# Altium schematic sheet LEDs.SchDoc
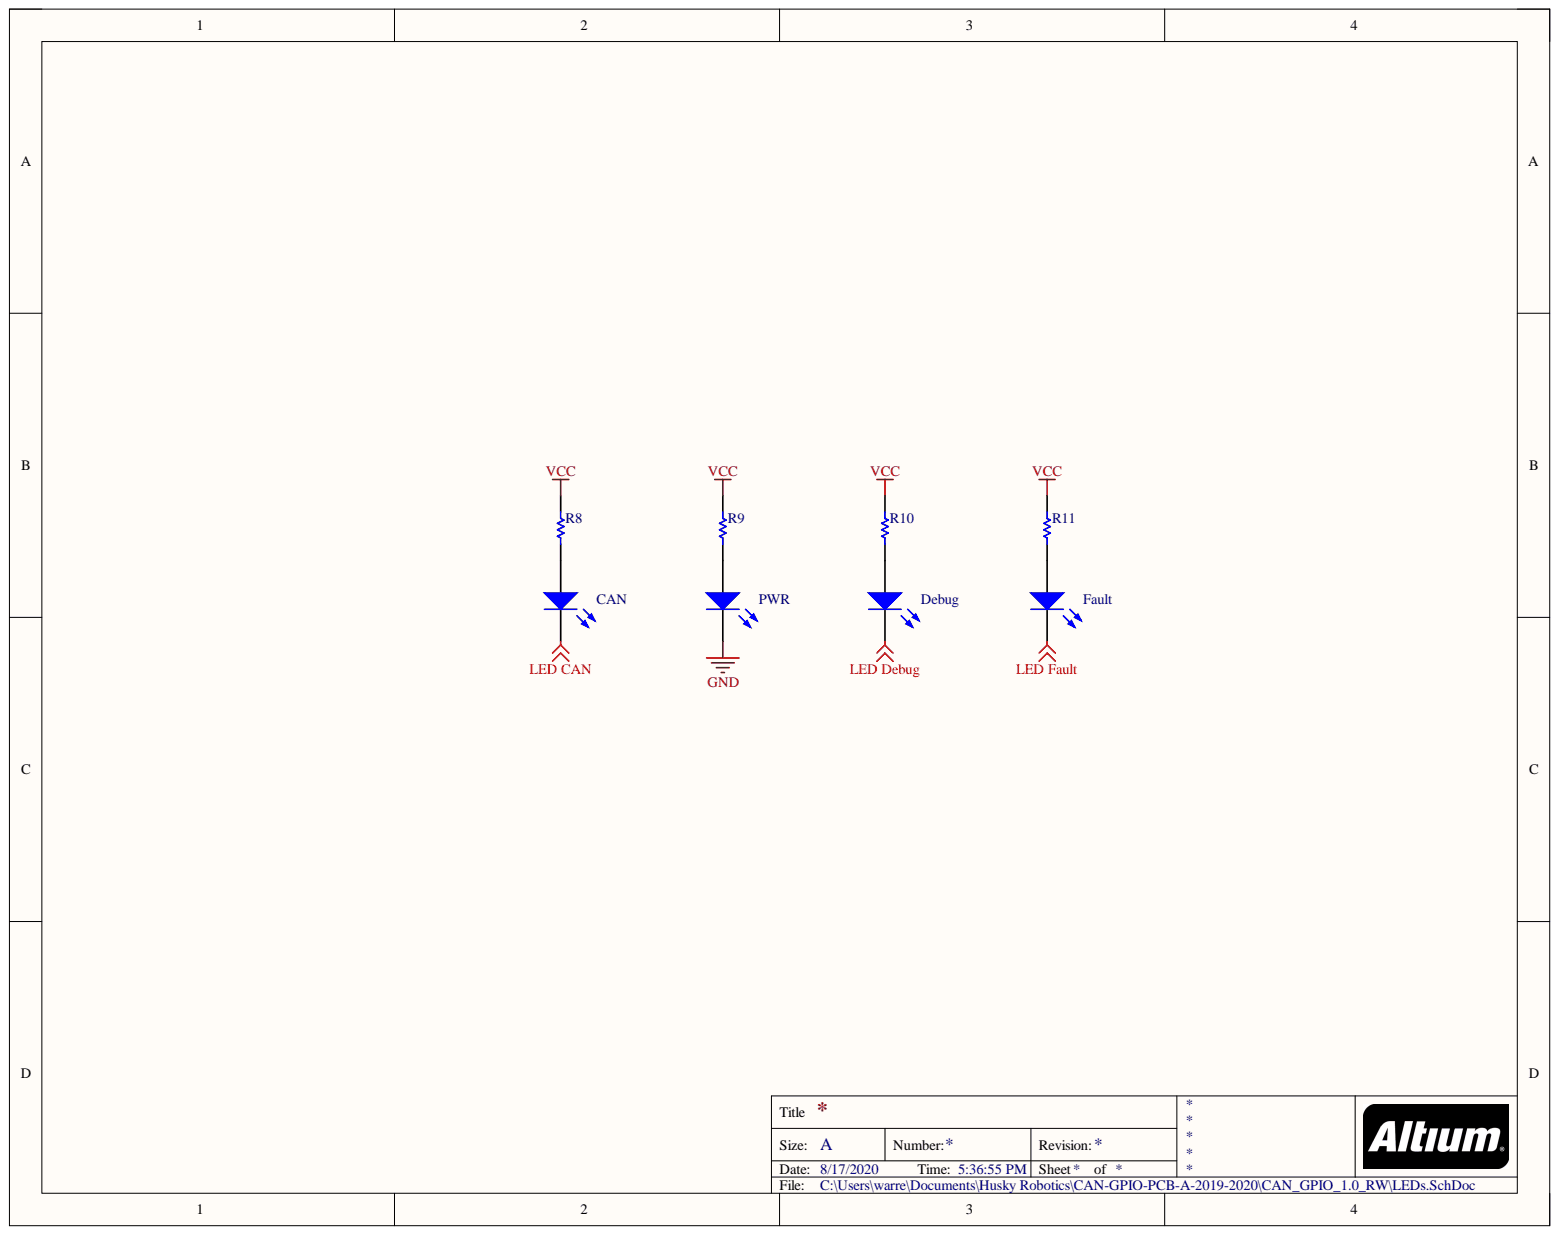
[diagram p0: LEDs.SchDoc]

## Parts
CAN  LED  [0805_A]
Debug  LED  [0805_A]
Fault  LED  [0805_A]
PWR  LED  [0805_A]  (value not drawn)
R8  Resistor 0805  [RESC0805(2012)_N]  (value not drawn)
R9  Resistor 0805  [RESC0805(2012)_N]  (value not drawn)
R10  Resistor 0805  [RESC0805(2012)_N]  (value not drawn)
R11  Resistor 0805  [RESC0805(2012)_N]  (value not drawn)

## Nets
GND: PWR.1
LED CAN: CAN.1
LED Debug: Debug.1
LED Fault: Fault.1
VCC: R8.1 R9.1 R10.1 R11.1
NetCAN_2: CAN.2 R8.2
NetDebug_2: Debug.2 R10.2
NetFault_2: Fault.2 R11.2
NetPWR_2: PWR.2 R9.2

## Library data of the parts
CAN Fault = LED: Standard 0805 LED; Manufacturer: Wuerth Elektronik; PartNumber: 150080SS75000; Component Kind: Standard; PackageReference: 0805_A; Library Name: Husky Robotics Standard Library 2019-2020.IntLib
  CAN: Color: Blue
  Fault: Color: Super Red; ComponentLink1Description: Manufacturer URL; ComponentLink1URL: http://www.we-online.com; ComponentLink2Description: Datasheet; ComponentLink2URL: http://katalog.we-online.com/led/datasheet/150080SS75000.pdf
Debug PWR = LED: Standard 0805 LED; Component Kind: Standard; PackageReference: 0805_A; Library Name: Husky Robotics Standard Library 2019-2020.IntLib
  Debug: Color: Green
  PWR: Color: Yellow
R8-R11 = Resistor 0805: Generic 0805 Resistor; Case-EIA: 0805; Case-Metric: 2012; Power: 0.125 W; Tolerance: 5 %; Value: 120R; Component Kind: Standard; Technology: SMT; Library Name: Husky Robotics Standard Library 2019-2020.IntLib
